# Altium schematic sheet [3]-MCU.SchDoc([3]-MCU)
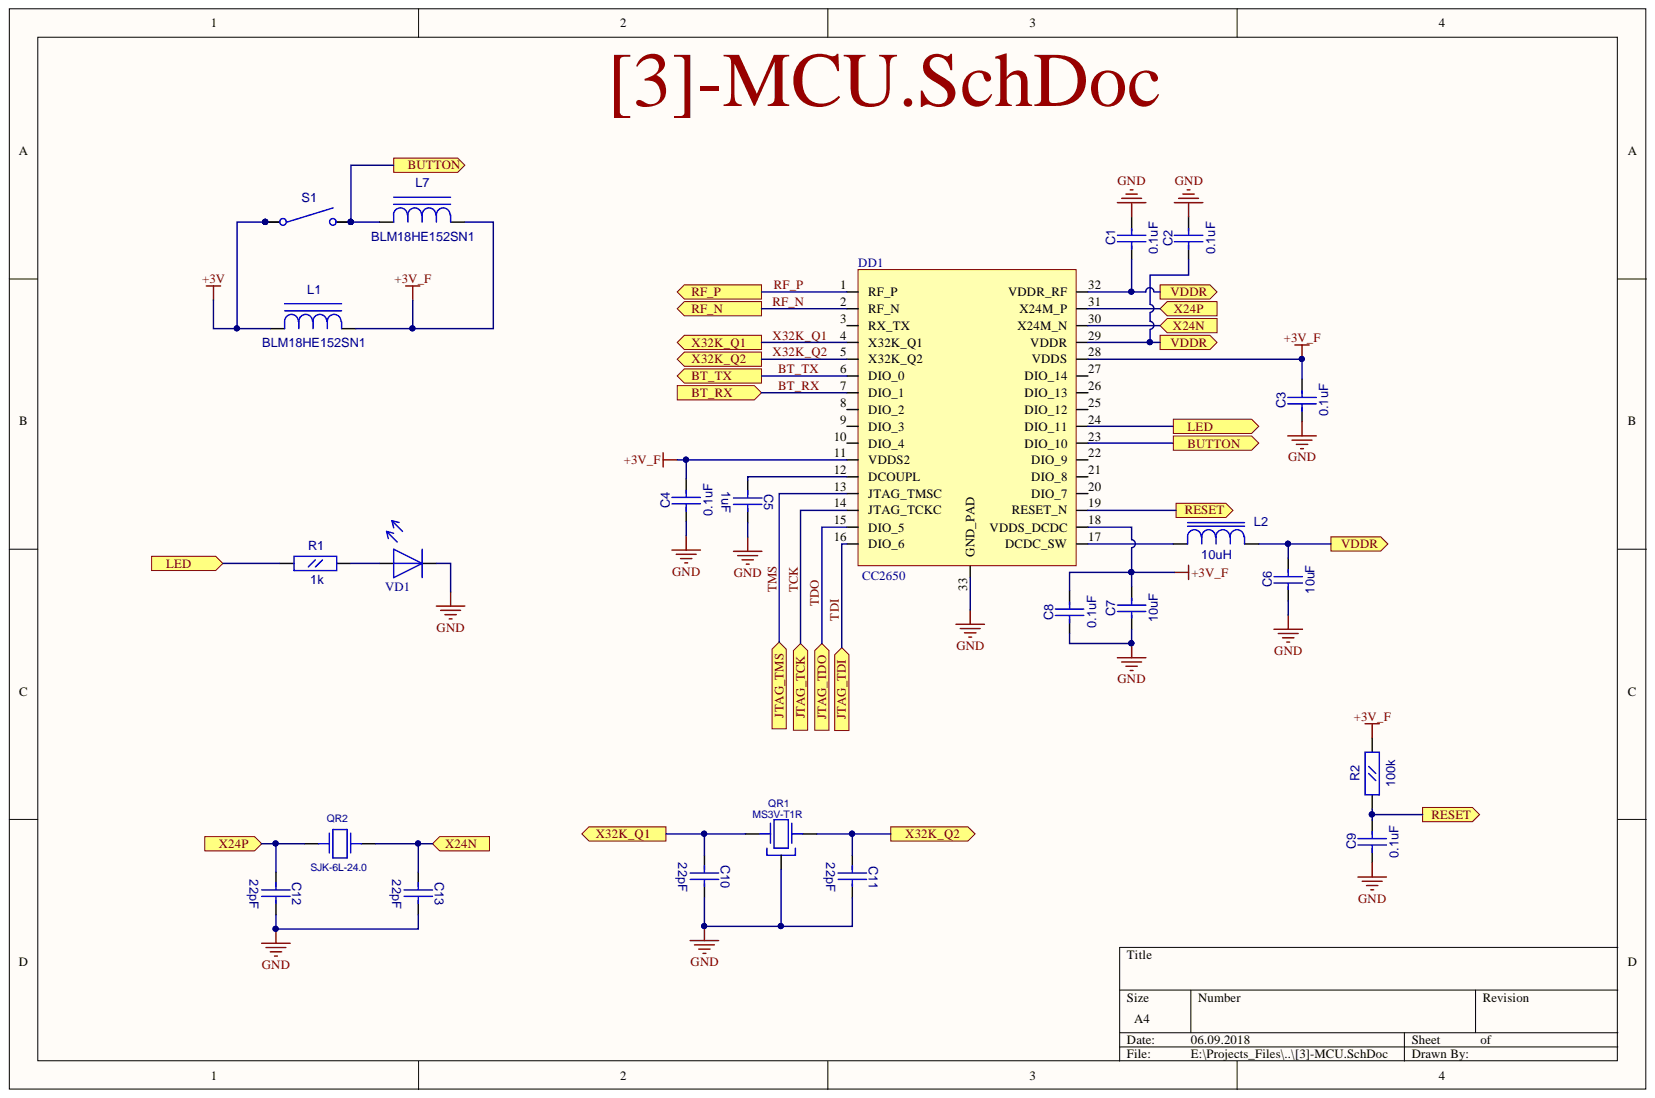
[diagram p0: [3]-MCU.SchDoc]

## Parts
C1  0.1uF  [CC0603]
C2  0.1uF  [CC0603]
C3  0.1uF  [CC0603]
C4  0.1uF  [CC0603]
C5  1uF  [CC0603]
C6  10uF  [CC0805]
C7  10uF  [CC0805]
C8  0.1uF  [CC0603]
C9  0.1uF  [CC0603]
C10  22pF  [CC0603]
C11  22pF  [CC0603]
C12  22pF  [CC0603]
C13  22pF  [CC0603]
DD1  CC2650  [QFN32_TI]
L1  BLM18HE152SN1  [L0603]
L2  10uH  [RC0805]
L7  BLM18HE152SN1  [L0603]
QR1  MS3V-T1R  [MS3V-T1R]
QR2  SJK-6L-24.0  [AC5032_2]
R1  1k  [RC0603]
R2  100k  [RC0603]
S1  IT-1147  [IT-1147]  (value not drawn)
VD1  LED_0805  [LED0805]  (value not drawn)

## Ports
BT_RX, BT_TX, BUTTON, JTAG_TCK, JTAG_TDI, JTAG_TDO, JTAG_TMS, LED, RESET, RF_N, RF_P, VDDR, X24N, X24P, X32K_Q1, X32K_Q2

## Nets
+3V: L1.1 S1.1 S1.2
+3V_F: C3.2 C4.2 C7.2 C8.2 DD1.11(VDDS2) DD1.18(VDDS_DCDC) DD1.28(VDDS) L1.2 L7.2 R2.2
GND: C1.2 C2.2 C3.1 C4.1 C5.2 C6.1 C7.1 C8.1 C9.1 C10.2 C11.2 C12.2 C13.2 DD1.33(GND_PAD) QR1.3 VD1.2
BT_RX: DD1.7(DIO_1) ; port BT_RX
BT_TX: DD1.6(DIO_0) ; port BT_TX
BUTTON: DD1.23(DIO_10) L7.1 S1.3 S1.4 ; port BUTTON
LED: DD1.24(DIO_11) R1.1 ; port LED
RESET: C9.2 DD1.19(RESET_N) R2.1 ; port RESET
RF_N: DD1.2(RF_N) ; port RF_N
RF_P: DD1.1(RF_P) ; port RF_P
TCK: DD1.14(JTAG_TCKC) ; port JTAG_TCK
TDI: DD1.16(DIO_6) ; port JTAG_TDI
TDO: DD1.15(DIO_5) ; port JTAG_TDO
TMS: DD1.13(JTAG_TMSC) ; port JTAG_TMS
VDDR: C1.1 C2.1 C6.2 DD1.29(VDDR) DD1.32(VDDR_RF) L2.2 ; port VDDR
X24N: C13.1 DD1.30(X24M_N) QR2.2 ; port X24N
X24P: C12.1 DD1.31(X24M_P) QR2.1 ; port X24P
X32K_Q1: C10.1 DD1.4(X32K_Q1) QR1.2 ; port X32K_Q1
X32K_Q2: C11.1 DD1.5(X32K_Q2) QR1.1 ; port X32K_Q2
NetC5_1: C5.1 DD1.12(DCOUPL)
NetDD1_17: DD1.17(DCDC_SW) L2.1
NetR1_2: R1.2 VD1.1

Not connected: DD1 3(RX_TX) 8(DIO_2) 9(DIO_3) 10(DIO_4) 20(DIO_7) 21(DIO_8) 22(DIO_9) 25(DIO_12) 26(DIO_13) 27(DIO_14)

## Library data of the parts
C1-C5 C8-C13 = CC0603: Description: CC0603; Component Kind: Standard; Library Name: gprs_modem_2.SchLib
  C1-C4 C8 C9: Value: 0.1uF
  C5: Value: 1uF
  C10-C13: Value: 22pF
C6 C7 = CC0805: Value: 10uF; Description: CC0805; Component Kind: Standard; Library Name: gprs_modem_2.SchLib
DD1 = CC2650RHB: CC2650RHBT; Value: CC2650; Component Kind: Standard; Library Name: gprs_modem_2.SchLib
L1 L7 = L0603: Value: BLM18HE152SN1; Description: L0603; Component Kind: Standard; Library Name: gprs_modem_2.SchLib
L2 = L0805: Value: 10uH; Description: L0805; Component Kind: Standard; Library Name: gprs_modem_2.SchLib
QR1 = MS3V-T1R: CRYSTAL_32768 Hz; Component Kind: Standard; Library Name: gprs_modem_2.SchLib
QR2 = AC5032_2: AC5032/2; Value: SJK-6L-24.0; Component Kind: Standard; Library Name: gprs_modem_2.SchLib
R1 R2 = RC0603: Description: RC0603; Component Kind: Standard
  R1: Value: 1k; Library Name: fizik_lib.SchLib
  R2: Value: 100k; Library Name: gprs_modem_2.SchLib
S1 = IT-1147: Switch, Single Pole, Single Throw, Normally Open; Value: {Value}; Component Kind: Standard; Library Name: fizik_lib.SchLib
VD1 = LED_0805: Value: LED_0805; Component Kind: Standard; Library Name: fizik_lib.SchLib
